# Altium schematic sheet latch.SchDoc
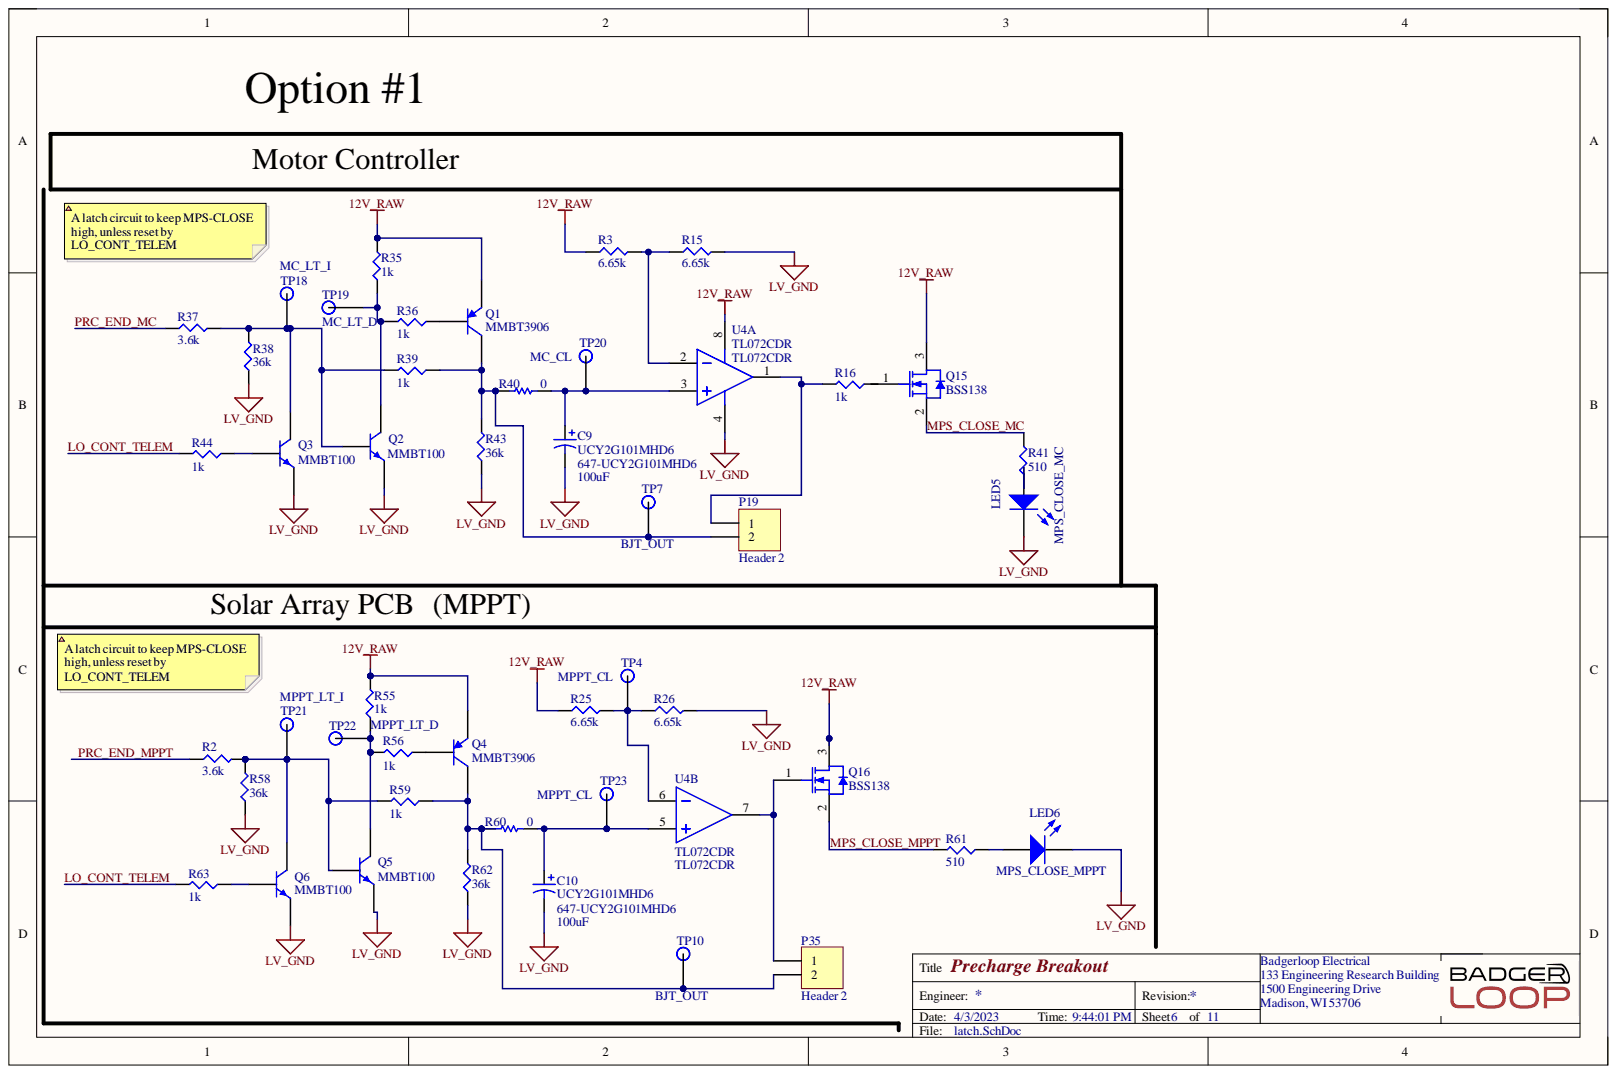
[diagram p0: latch.SchDoc]

## Parts
C9  UCY2G101MHD6  Capacitance: 100uF  Supplier Part Number 1: 647-UCY2G101MHD6  [UCY2G101MHD6]
C10  UCY2G101MHD6  Capacitance: 100uF  Supplier Part Number 1: 647-UCY2G101MHD6  [UCY2G101MHD6]
LED5  MPS_CLOSE_MC  [LED 0603 ORANGE]
LED6  MPS_CLOSE_MPPT  [LED 0603 ORANGE]
P19  Header 2  [HDR1X2]
P35  Header 2  [HDR1X2]
Q1  MMBT3906  [FAIR-SOT23-3_V]
Q2  MMBT100  [SOTFL103P240X110-3N]
Q3  MMBT100  [SOTFL103P240X110-3N]
Q4  MMBT3906  [FAIR-SOT23-3_V]
Q5  MMBT100  [SOTFL103P240X110-3N]
Q6  MMBT100  [SOTFL103P240X110-3N]
Q15  BSS138  [FAIR-SOT23-3_V]
Q16  BSS138  [FAIR-SOT23-3_V]
R2  value 3.6k  [SMD-0805-RES]  (hidden comment: ERJ-6ENF3601V)
R3  6.65k  [SMD-0805-RES]
R15  6.65k  [SMD-0805-RES]
R16  value 1k  [SMD-0805-RES]  (hidden comment: ERJ-P06F1001V)
R25  6.65k  [SMD-0805-RES]
R26  6.65k  [SMD-0805-RES]
R35  value 1k  [SMD-0805-RES]  (hidden comment: ERJ-P06F1001V)
R36  value 1k  [SMD-0805-RES]  (hidden comment: ERJ-P06F1001V)
R37  value 3.6k  [SMD-0805-RES]  (hidden comment: ERJ-6ENF3601V)
R38  value 36k  [SMD-0805-RES]  (hidden comment: ERJ-6ENF3602V)
R39  value 1k  [SMD-0805-RES]  (hidden comment: ERJ-P06F1001V)
R40  value 0  [RESC2013X60X35ML10T20]  (hidden comment: Resistor 0R +/- 5% 0805 125 mW)
R41  value 510  [SMD-0805-RES]  (hidden comment: ERJ-P06J511V)
R43  value 36k  [SMD-0805-RES]  (hidden comment: ERJ-6ENF3602V)
R44  value 1k  [SMD-0805-RES]  (hidden comment: ERJ-P06F1001V)
R55  value 1k  [SMD-0805-RES]  (hidden comment: ERJ-P06F1001V)
R56  value 1k  [SMD-0805-RES]  (hidden comment: ERJ-P06F1001V)
R58  value 36k  [SMD-0805-RES]  (hidden comment: ERJ-6ENF3602V)
R59  value 1k  [SMD-0805-RES]  (hidden comment: ERJ-P06F1001V)
R60  value 0  [RESC2013X60X35ML10T20]  (hidden comment: Resistor 0R +/- 5% 0805 125 mW)
R61  value 510  [SMD-0805-RES]  (hidden comment: ERJ-P06J511V)
R62  value 36k  [SMD-0805-RES]  (hidden comment: ERJ-6ENF3602V)
R63  value 1k  [SMD-0805-RES]  (hidden comment: ERJ-P06F1001V)
TP4  MPPT_CL  [Test Point]
TP7  BJT_OUT  [Test Point]
TP10  BJT_OUT  [Test Point]
TP18  MC_LT_I  [Test Point]
TP19  MC_LT_D  [Test Point]
TP20  MC_CL  [Test Point]
TP21  MPPT_LT_I  [Test Point]
TP22  MPPT_LT_D  [Test Point]
TP23  MPPT_CL  [Test Point]
U4  TL072CDR  [SOP127P600X175-8N]  (parts A,B)

## Nets
12V_RAW: Q1.2 Q4.2 Q15.3 Q16.3 R3.1 R25.1 R35.2 R55.2 U4.8
LV_GND: C9.1 C10.1 LED5.2 LED6.2 Q2.2 Q3.2 Q5.2 Q6.2 R15.2 R26.2 R38.1 R43.1 R58.1 R62.1 U4.4
LO_CONT_TELEM: R44.2 R63.2
MPS_CLOSE_MC: Q15.2 R41.2
PRC_END_MC: R37.1
PRC_END_MPPT: R2.1
NetC9_2: C9.2 R40.1 TP20.1 U4.3
NetC10_2: C10.2 R60.1 TP23.1 U4.5
NetLED5_1: LED5.1 R41.1
NetLED6_1: LED6.1 R61.1
NetP19_1: P19.1 R16.2 U4.1
NetP19_2: P19.2 Q1.3 R39.1 R40.2 R43.2 TP7.1
NetP35_1: P35.1 Q16.1 U4.7
NetP35_2: P35.2 Q4.3 R59.1 R60.2 R62.2 TP10.1
NetQ1_1: Q1.1 R36.1
NetQ2_1: Q2.1 Q3.3 R37.2 R38.2 R39.2 TP18.1
NetQ2_3: Q2.3 R35.1 R36.2 TP19.1
NetQ3_1: Q3.1 R44.1
NetQ4_1: Q4.1 R56.1
NetQ5_1: Q5.1 Q6.3 R2.2 R58.2 R59.2 TP21.1
NetQ5_3: Q5.3 R55.1 R56.2 TP22.1
NetQ6_1: Q6.1 R63.1
NetQ16_2: Q16.2 R61.2
NetR3_2: R3.2 R15.1 U4.2
NetR25_2: R25.2 R26.1 TP4.1 U4.6
MPS_CLOSE_MPPT:

Not connected: Q15 1; R16 1

## Library data of the parts
C9 C10 = UCY2G101MHD6: Cap Aluminum Lytic 100uF 400V 20% (18 X 31.5mm) Radial 7.5mm 875mA 12000h 105C Automotive Bulk; Manufacturer: Nichicon; Dissipation Factor: 0.24%; Life (Hours): 10000Hour; Polarity: Polar; Case/Package: Radial; Category: Aluminum Electrolytic Capacitors; Component Kind: Standard; ComponentLink1Description: https://datasheet.ciiva.com/11675/e-cy-11675845.pdf?src-supplier=Avnet; ComponentLink1URL: https://datasheet.ciiva.com/11675/e-cy-11675845.pdf?src-supplier=Avnet; ComponentLink2Description: https://datasheet.ciiva.com/17522/e-cy-17522213.pdf?src-supplier=Avnet+Europe; ComponentLink2URL: https://datasheet.ciiva.com/17522/e-cy-17522213.pdf?src-supplier=Avnet+Europe; Diameter: 18mm; Features: General Purpose; Height: 31.5mm; Height - Seated (Max): 1.299inch; Lead Pitch: 7.5mm; Length: 31.5mm; Library Name: capacitors.SchLib; Manufacturer Part Number: UCY2G101MHD6; Max Operating Temperature: 105°C; Min Operating Temperature: -40°C; Mount: Through Hole; Package Quantity: 50; Packaging: Bulk; Pricing 1: 1=4.44000, 10=3.09000, 50=3.09000, 100=2.08000, 600=1.86000, 1000=1.86000, 10000=1.86000 (USD); Radiation Hardening: No; Ripple Current: 875mA; RoHS: Yes; RoHS Compliant: Yes; Series: UCY; Stock 1: 17; Supplier 1: Mouser; Termination: Radial; Tolerance: 20%; Voltage Rating: 400V; Voltage Rating (DC): 400V
LED5 LED6 = CMP-040-000018-1: A-0603UOC; Manufacturer: Lighthouse LEDs; Color: ORANGE; Minimum Quantity: 1; Availability: In Stock; Component Kind: Standard; ComponentLink1Description: Manufacturer; ComponentLink1URL: https://lighthouseleds.com/0603-smd-led-orange-ultra-bright-170-mcd.html; Datasheets: https://lighthouseleds.com/downloads/dl/file/id/11/0603_orange_amber_smd_led_datasheet.pdf; Design Item ID: LED ORANGE 0603; Library Name: Altium Concord Pro on A365; Manufacturer P/N: A-0603UOC; Package / Case: 0603; Source: misc.SchLib
P19 P35 = Header 2: Header, 2-Pin; Component Kind: Standard; LatestRevisionDate: 17-Jul-2002; LatestRevisionNote: Re-released for DXP Platform.; Library Name: Miscellaneous Connectors.IntLib; Signal Integrity: Connector; Publisher: Altium Limited
Q1 Q4 = CMP-013-00008-2: PNP General-Purpose Amplifier, 40 V, 0.2 A, -55 to 150 degC, 3-Pin SOT23, RoHS, Tape and Reel; Manufacturer: Fairchild; Elements: 1; Frequency: 250MHz; hFE Min: 100; Package Version: Rev. 12, 03/2016; Power: 350mW; Vce Max: 400mV; Case/Package: TO-236-3; Component Kind: Standard; Datasheet URL: https://www.fairchildsemi.com/datasheets/MM/MMBT3906.pdf; Datasheet Version: Rev. 1.2.2, 04/2014; Library Name: Altium Concord Pro on A365; Max Operating Temperature: 150°C; Min Operating Temperature: -55°C; Mounting Technology: SM; Package Description: 3-Pin Small Outline Transistor, Body 2.92 x 1.325 mm, Pitch 0.95 mm; Package Quantity: 3000; Package Reference: SOT23-3; Packaging: TapeandReel; Pins: 3; RoHS Compliant: True
Q2 Q3 Q5 Q6 = CMP-040-000001-1: Small Signal Bipolar Transistor, 0.5A I(C), 45V V(BR)CEO, 1-Element, NPN, Silicon; Manufacturer: ON Semiconductor / Fairchild; Collector-base Capacitance-Max: 4.5pF; DC Current Gain-Min (hFE): 100; Max Collector Current: 500mA; Number of Elements: 1; Package Body Material: Plastic; Power Dissipation-Max (Abs): 350mW; Qualification Status: Not Qualified; Transistor Application: AMPLIFIER; Transition Frequency-Nom (fT): 250MHz; VCEsat-Max: 200mV; Category: BJTs; Collector-emitter Voltage-Max: 45V; Component Kind: Standard; ComponentLink1Description: https://datasheet.ciiva.com:443/pdfs/VipMasterIC/IC/ONSM/ONSM-S-A0003589371/ONSM-S-A0003589371-1.pdf?src-supplier=IHS+Markit; ComponentLink1URL: https://datasheet.ciiva.com:443/pdfs/VipMasterIC/IC/ONSM/ONSM-S-A0003589371/ONSM-S-A0003589371-1.pdf?src-supplier=IHS+Markit; ComponentLink2Description: https://datasheet.ciiva.com/4721/mmbt100-196018-4721422.pdf?src-supplier=Mouser; ComponentLink2URL: https://datasheet.ciiva.com/4721/mmbt100-196018-4721422.pdf?src-supplier=Mouser; ComponentLink3Description: https://datasheet.ciiva.com/11206/pn100a-11206125.pdf?src-supplier=Avnet; ComponentLink3URL: https://datasheet.ciiva.com/11206/pn100a-11206125.pdf?src-supplier=Avnet; ComponentLink4Description: https://datasheet.ciiva.com/26114/pn100a-26114236.pdf?src-supplier=Avnet+Europe; ComponentLink4URL: https://datasheet.ciiva.com/26114/pn100a-26114236.pdf?src-supplier=Avnet+Europe; ComponentLink5Description: https://datasheet.ciiva.com/11198/pn100a-11198959.pdf?src-supplier=Avnet; ComponentLink5URL: https://datasheet.ciiva.com/11198/pn100a-11198959.pdf?src-supplier=Avnet; ComponentLink6Description: https://datasheet.ciiva.com/6361/mmbt100-6361016.pdf?src-supplier=Digi-Key; ComponentLink6URL: https://datasheet.ciiva.com/6361/mmbt100-6361016.pdf?src-supplier=Digi-Key; ComponentLink7Description: https://datasheet.ciiva.com/6356/mmbt100-6356120.pdf?src-supplier=Digi-Key; ComponentLink7URL: https://datasheet.ciiva.com/6356/mmbt100-6356120.pdf?src-supplier=Digi-Key; ComponentLink8Description: https://datasheet.ciiva.com/6361/mmbt100-6361014.pdf?src-supplier=Digi-Key; ComponentLink8URL: https://datasheet.ciiva.com/6361/mmbt100-6361014.pdf?src-supplier=Digi-Key; Design Item ID: MMBT100; JESD-30 Code: R-PDSO-G3; Library Name: Altium Concord Pro on A365; Manufacturer Part Number: MMBT100; Max Operating Temperature: 150°C; Moisture Sensitivity Level: 1; Number of Pins: 3; Package Shape: Rectangular; Package Style: SMALL OUTLINEMeter; Polarity/Channel Type: NPN; Pricing 1: 1=0.35700, 5=0.35700, 10=0.24700, 100=0.10500 (USD); REACH SVHC: Yes; RoHS: Yes; RoHS Compliant: Yes; Source: misc.SchLib; Stock 1: 66397; Surface Mount: Yes; Terminal Form: Gull Wing; Terminal Position: DUAL; Transistor Element Material: SILICON
Q15 Q16 = CMP-013-00021-4: N-Channel Logic Level Enhancement Mode Field Effect Transistor, 220 mA, 50 V, -55 to 150 degC, 3-Pin SOT-23, RoHS, Tape and Reel; Manufacturer: Fairchild; Elements: 1; Input Capacitance: 27pF; Nominal Dual Supply Voltage: 50V; Nominal Vgs: 1.3V; Package Version: Rev. 12, 03/2016; Power: 360mW; Rds On Max: 3.5Ohm; Resistance: 0.7Ohm; Typical Rise Time: 9ns; Case/Package: TO-236-3; Component Kind: Standard; Datasheet URL: https://www.fairchildsemi.com/datasheets/BS/BSS138.pdf; Datasheet Version: Rev. C, 10/2005; Library Name: Altium Concord Pro on A365; Max Operating Temperature: 150°C; Min Operating Temperature: -55°C; Mounting Technology: SM; Package Description: 3-Pin Small Outline Transistor, Body 2.92 x 1.325 mm, Pitch 0.95 mm; Package Quantity: 1; Package Reference: SOT23-3; Packaging: TapeandReel; Pins: 3; RoHS Compliant: True
R2 R37 = CMP-009-01342-1: RES SMD 3.6K OHM 1% 1/8W 0805; Manufacturer: Panasonic Electronic Components; @ qty: 1500; Factory Stock: 0; Minimum Quantity: 1; Power (Watts): 0.125W, 1/8W; Temperature Coefficient: ±100ppm/°C; Unit Price (USD): 0.00729; Component Kind: Standard; Composition: Thick Film; Datasheets: http://industrial.panasonic.com/www-cgi/jvcr13pz.cgi?E+PZ+3+AOA0002+ERJ6ENF3601V+7+WW; Design Item ID: ERJ-6ENF3601V; Digi-Key Part Number: P3.60KCCT-ND; Failure Rate: -; Features: Automotive AEC-Q200; Height - Seated (Max): 0.028" (0.70mm); Image: //media.digikey.com/Renders/Panasonic%20Renders/ERJ-0805%20Pkg.jpg; Library Name: Altium Concord Pro on A365; Number of Terminations: 2; Operating Temperature: -55°C ~ 155°C; Package / Case: 0805 (2012 Metric); Packaging: Cut Tape (CT); Part Number: ERJ-6ENF3601V; Part Status: Active; Quantity Available: 32253; Series: ERJ; Size / Dimension: 0.079" L x 0.049" W (2.00mm x 1.25mm); Source: resistors.SchLib; Supplier Device Package: 0805; Tolerance: 1%
R3 R15 R25 R26 = ERJ-6ENF6651V: RES SMD 6.65K OHM 1% 1/8W 0805; Manufacturer: Panasonic Electronic Components; @ qty: 1500; Factory Stock: 0; Minimum Quantity: 1; Power (Watts): 0.125W, 1/8W; Temperature Coefficient: ±100ppm/°C; Unit Price (USD): 0.00729; Component Kind: Standard; Composition: Thick Film; Datasheets: http://industrial.panasonic.com/www-cgi/jvcr13pz.cgi?E+PZ+3+AOA0002+ERJ6ENF6651V+7+WW; Digi-Key Part Number: P6.65KCCT-ND; Failure Rate: -; Features: Automotive AEC-Q200; Height - Seated (Max): 0.028" (0.70mm); Image: //media.digikey.com/Renders/Panasonic%20Renders/ERJ-0805%20Pkg.jpg; Library Name: resistors.SchLib; Number of Terminations: 2; Operating Temperature: -55°C ~ 155°C; Package / Case: 0805 (2012 Metric); Packaging: Cut Tape (CT); Part Number: ERJ-6ENF6651V; Part Status: Active; Quantity Available: 36879; Series: ERJ; Size / Dimension: 0.079" L x 0.049" W (2.00mm x 1.25mm); Supplier Device Package: 0805; Tolerance: ±1%; Value: 6.65k
R16 R35 R36 R39 R44 R55 R56 R59 R63 = CMP-009-02659-1: RES SMD 1K OHM 1% 1/2W 0805; Manufacturer: Panasonic Electronic Components; @ qty: 1500; Factory Stock: 0; Minimum Quantity: 1; Power (Watts): 0.5W, 1/2W; Temperature Coefficient: ±100ppm/°C; Unit Price (USD): 0.02534; Component Kind: Standard; Composition: Thick Film; Datasheets: https://industrial.panasonic.com/cdbs/www-data/pdf/RDA0000/AOA0000C243.pdf; Design Item ID: ERJ-P06F1001V; Digi-Key Part Number: P16058CT-ND; Failure Rate: -; Features: Automotive AEC-Q200, Pulse Withstanding; Height - Seated (Max): 0.028" (0.70mm); Image: //media.digikey.com/Renders/Panasonic%20Renders/ERJ-0805%20Pkg.jpg; Library Name: Altium Concord Pro on A365; Number of Terminations: 2; Operating Temperature: -55°C ~ 155°C; Package / Case: 0805 (2012 Metric); Packaging: Cut Tape (CT); Part Number: ERJ-P06F1001V; Part Status: Active; Quantity Available: 161264; Series: ERJ; Size / Dimension: 0.079" L x 0.049" W (2.00mm x 1.25mm); Source: resistors.SchLib; Supplier Device Package: 0805; Tolerance: 1%
R38 R43 R58 R62 = CMP-009-01343-1: RES SMD 36K OHM 1% 1/8W 0805; Manufacturer: Panasonic Electronic Components; @ qty: 1500; Factory Stock: 0; Minimum Quantity: 1; Power (Watts): 0.125W, 1/8W; Temperature Coefficient: ±100ppm/°C; Unit Price (USD): 0.00729; Component Kind: Standard; Composition: Thick Film; Datasheets: http://industrial.panasonic.com/www-cgi/jvcr13pz.cgi?E+PZ+3+AOA0002+ERJ6ENF3602V+7+WW; Design Item ID: ERJ-6ENF3602V; Digi-Key Part Number: P36.0KCCT-ND; Failure Rate: -; Features: Automotive AEC-Q200; Height - Seated (Max): 0.028" (0.70mm); Image: //media.digikey.com/Renders/Panasonic%20Renders/ERJ-0805%20Pkg.jpg; Library Name: Altium Concord Pro on A365; Number of Terminations: 2; Operating Temperature: -55°C ~ 155°C; Package / Case: 0805 (2012 Metric); Packaging: Cut Tape (CT); Part Number: ERJ-6ENF3602V; Part Status: Active; Quantity Available: 42552; Series: ERJ; Size / Dimension: 0.079" L x 0.049" W (2.00mm x 1.25mm); Source: resistors.SchLib; Supplier Device Package: 0805; Tolerance: 1%
R40 R60 = CMP-009-00007-5: Chip Resistor, 0 Ohm, 0.125 W, -55 to 155 degC, 0805 (2012 Metric); Power: 125mW; Voltage Rating: 50V; Case/Package: 0805; Component Kind: Standard; Library Name: Altium Concord Pro on A365; Max Operating Temperature: 155°C; Min Operating Temperature: -55°C; Mounting Technology: SM; Pins: 2; RoHS Compliant: True; Tolerance: 5%
R41 R61 = CMP-009-00645-1: RES SMD 510 OHM 5% 1/2W 0805; Manufacturer: Panasonic Electronic Components; @ qty: 1500; Factory Stock: 0; Minimum Quantity: 1; Power (Watts): 0.5W, 1/2W; Temperature Coefficient: ±200ppm/°C; Unit Price (USD): 0.01839; Component Kind: Standard; Composition: Thick Film; Datasheets: https://industrial.panasonic.com/cdbs/www-data/pdf/RDA0000/AOA0000C243.pdf; Design Item ID: ERJ-P06J511V; Digi-Key Part Number: P510ADCT-ND; Failure Rate: -; Features: Automotive AEC-Q200, Pulse Withstanding; Height - Seated (Max): 0.028" (0.70mm); Image: //media.digikey.com/Renders/Panasonic%20Renders/ERJ-0805%20Pkg.jpg; Library Name: Altium Concord Pro on A365; Number of Terminations: 2; Operating Temperature: -55°C ~ 155°C; Package / Case: 0805 (2012 Metric); Packaging: Cut Tape (CT); Part Number: ERJ-P06J511V; Part Status: Active; Quantity Available: 54515; Series: ERJ; Size / Dimension: 0.079" L x 0.049" W (2.00mm x 1.25mm); Source: resistors.SchLib; Supplier Device Package: 0805; Tolerance: 5%
TP4 TP7 TP10 TP18-TP23 = CMP-039-000000-1: SMD TEST POINT; Component Kind: Standard; Design Item ID: TP; Library Name: Altium Concord Pro on A365; Source: misc.SchLib
U4 = CMP-014-00006-1: IC OPAMP JFET 3MHZ 8SOIC; Manufacturer: Texas Instruments; Common Mode Rejection Ratio: 70dB; Dual Supply Voltage: 9V; Input Bias Current: 200pA; Input Offset Voltage (Vos): 10mV; Max Supply Voltage: 18V; Min Supply Voltage: 3.5V; Nominal Supply Current: 5mA; Number of Amplifiers: 2; Operating Supply Current: 1.4mA; Slew Rate: 13V/µs; Voltage Gain: 106.02dB; Bandwidth: 3MHz; Case/Package: D; Component Kind: Standard; ComponentLink1Description: http://www.ti.com/lit/ds/symlink/tl071a.pdf?src-supplier=Digi-Key; ComponentLink1URL: http://www.ti.com/lit/ds/symlink/tl071a.pdf?src-supplier=Digi-Key; ComponentLink2Description: http://www.ti.com/general/docs/lit/getliterature.tsp?genericPartNumber=TL072&&fileType=pdf&src-supplier=Avnet; ComponentLink2URL: http://www.ti.com/general/docs/lit/getliterature.tsp?genericPartNumber=TL072&&fileType=pdf&src-supplier=Avnet; ComponentLink3Description: http://www.farnell.com/datasheets/1882732.pdf?src-supplier=Element14; ComponentLink3URL: http://www.farnell.com/datasheets/1882732.pdf?src-supplier=Element14; Contact Plating: Gold; Design Item ID: TL072CDR; Gain Bandwidth Product: 3MHz; Height: 1.58mm; Lead Free: Lead Free; Length: 4.9mm; Library Name: Altium Concord Pro on A365; Manufacturer Part Number: TL072CDR; Max I/O Voltage: 10mV; Max Operating Temperature: 85°C; Min Operating Temperature: -40°C; Nominal Gain Bandwidth Product: 3MHz; Number of Channels: 2; Number of Circuits: 2; Number of Elements: 2; Package Quantity: 1; Packaging: Tape and Reel; Pins: 8; Pricing 1: 1=0.41, 10=0.334, 100=0.2059, 500=0.1562, 1000=0.11715 (USD); Radiation Hardening: No; REACH SVHC: No SVHC; Source: ic.SchLib; Stock 1: 21821; Unity Gain Bandwidth Product: 3MHz; Width: 3.91mm
